# Altium schematic sheet Sheet3.SchDoc(Sheet3)
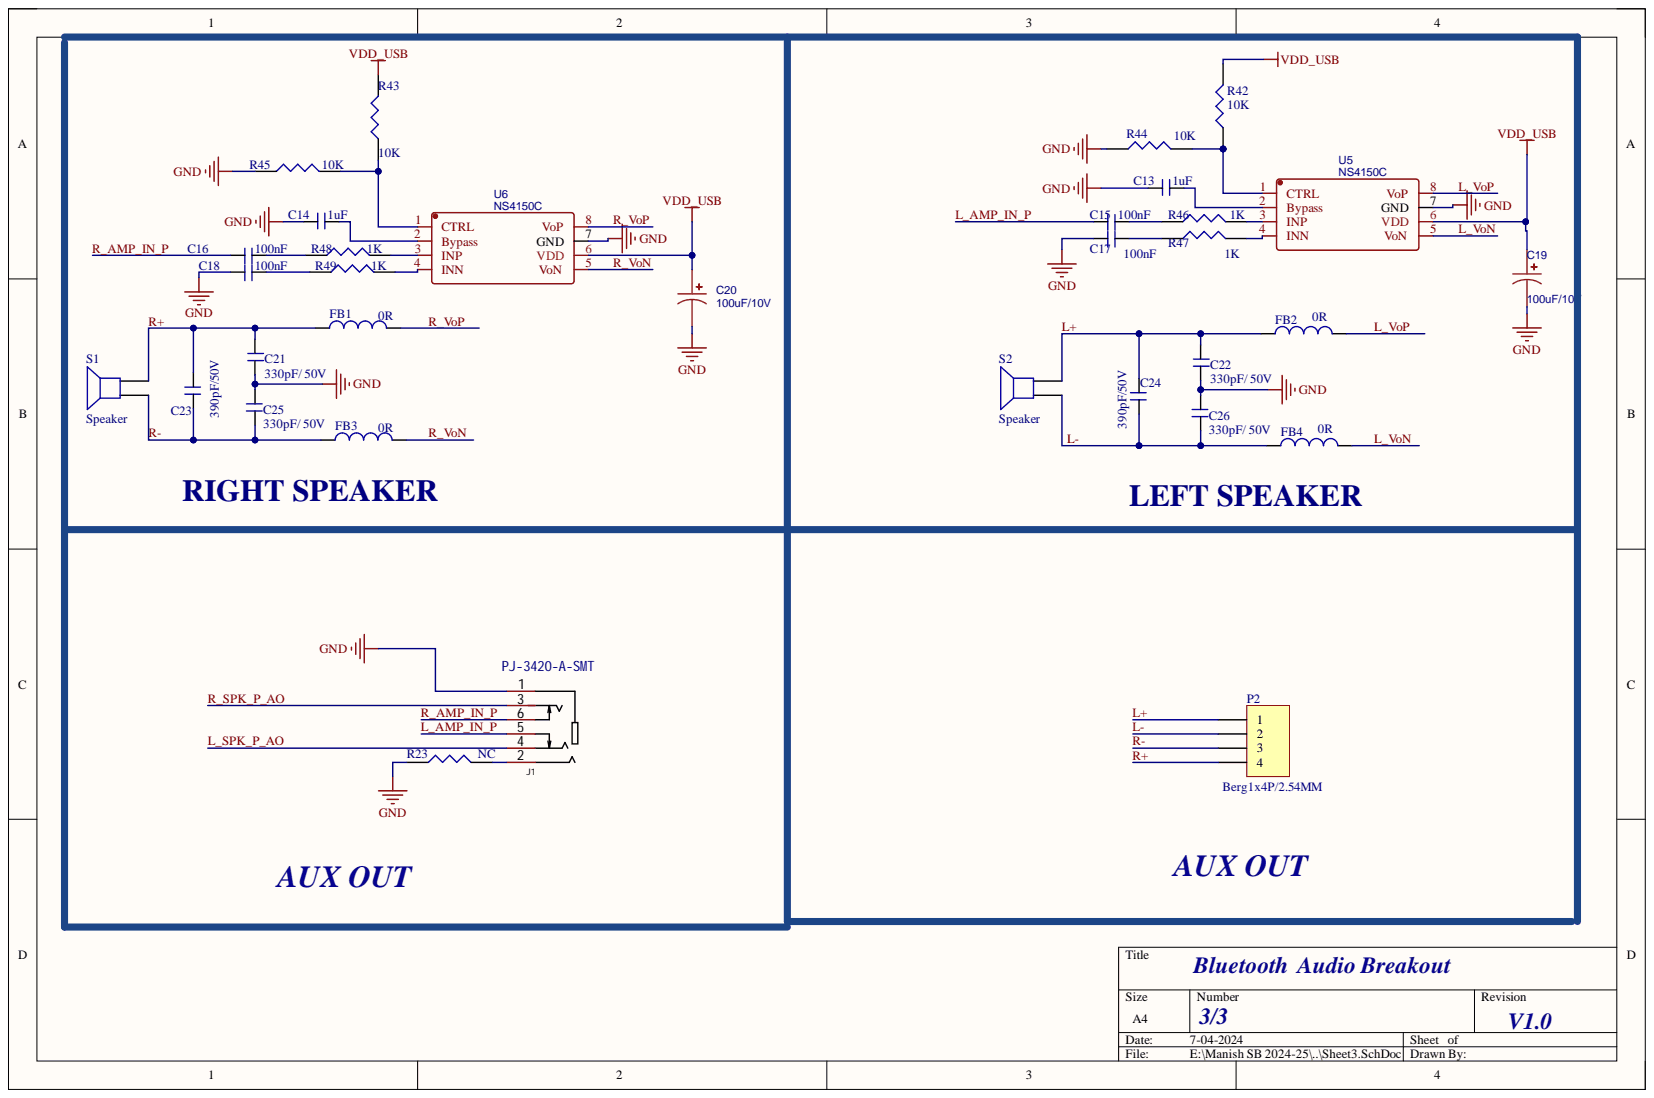
[diagram p0: Sheet3.SchDoc]

## Parts
C13  1uF  [C_0603]
C14  1uF  [C_0603]
C15  100nF  [C_0603]
C16  100nF  [C_0603]
C17  100nF  [C_0603]
C18  100nF  [C_0603]
C19  100uF/10V  [CASE-B-3528]
C20  100uF/10V  [CASE-B-3528]
C21  330pF/ 50V  [C_0603]
C22  330pF/ 50V  [C_0603]
C23  390pF/50V  [C_0603]
C24  390pF/50V  [C_0603]
C25  330pF/ 50V  [C_0603]
C26  330pF/ 50V  [C_0603]
FB1  0R  [R_0603]
FB2  FB  value 0R  [R_0603]
FB3  FB  value 0R  [R_0603]
FB4  FB  value 0R  [R_0603]
J1  PJ-3420-A-SMT  [3.5mm_PJ-3420]
P2  Berg1x4P/2.54MM  [4P_TH_2.54MM]
R23  NC  [R_0603]
R42  10K  [R_0603]
R43  10K  [R_0603]
R44  10K  [R_0603]
R45  10K  [R_0603]
R46  1K  [R_0603]
R47  1K  [R_0603]
R48  1K  [R_0603]
R49  1K  [R_0603]
S1  Speaker  [JST2P_P2.0mm]
S2  Speaker  [JST2P_P2.0mm]
U5  NS4150C  [SOP 8P]
U6  NS4150C  [SOP 8P]

## Nets
GND: C13.1 C14.1 C17.2 C18.2 C19.2 C20.2 C21.2 C22.2 C25.2 C26.2 J1.1 R23.2 R44.1 R45.1 U5.7(GND) U6.7(GND)
VDD_USB: C19.1 C20.1 R42.2 R43.2 U5.6(VDD) U6.6(VDD)
L+: C22.1 C24.2 FB2.1 P2.1 S2.2
L-: C24.1 C26.1 FB4.1 P2.2 S2.1
L_AMP_IN_P: C15.2 J1.5
L_SPK_P_AO: J1.4
L_VoN: FB4.2 U5.5(VoN)
L_VoP: FB2.2 U5.8(VoP)
R+: C21.1 C23.2 FB1.1 P2.4 S1.2
R-: C23.1 C25.1 FB3.1 P2.3 S1.1
R_AMP_IN_P: C16.2 J1.6
R_SPK_P_AO: J1.3
R_VoN: FB3.2 U6.5(VoN)
R_VoP: FB1.2 U6.8(VoP)
NetC13_2: C13.2 U5.2(Bypass)
NetC14_2: C14.2 U6.2(Bypass)
NetC15_1: C15.1 R46.1
NetC16_1: C16.1 R48.1
NetC17_1: C17.1 R47.1
NetC18_1: C18.1 R49.1
NetJ1_2: J1.2 R23.1
NetR42_1: R42.1 R44.2 U5.1(CTRL)
NetR43_1: R43.1 R45.2 U6.1(CTRL)
NetR46_2: R46.2 U5.3(INP)
NetR47_2: R47.2 U5.4(INN)
NetR48_2: R48.2 U6.3(INP)
NetR49_2: R49.2 U6.4(INN)

## Library data of the parts
C13-C18 C21-C26 = CAP_NONPOLAR: Component Kind: Standard; Library Name: dxp_pasl_lib.IntLib
C19 C20 = 270uF: BOM_Manufacturer: rubycon(红宝石); BOM_Manufacturer Part: 100ZL270MEFC12.5X35; Contributor: lcsc; nameAlias: Capacitance; BOM_JLCPCB Part Class: Extended Part; BOM_Supplier: LCSC; BOM_Supplier Part: C1598036; Component Kind: Standard; link: https://atta.szlcsc.com/upload/public/pdf/source/20210810/D32E969E718DCFB364F518B2A745C406.pdf; spicePre: C; spiceSymbolName: 100ZL270MEFC12.5X35; Library Name: MIX.SchLib
FB1-FB4 = Inductor: Code_IEC: 1005; Code_JEITA: 0402; Component Kind: Standard; Description: Inductor; LatestRevisionDate: 29-May-2009; LatestRevisionNote: IPC-7351 Footprint Added.; Library Name: Miscellaneous Devices.IntLib; PackageDescription: Chip Inductor; PackageReference: 0402-A; Published: 8-Jun-2000; Signal Integrity: Inductor; Simulation: INDUCTOR; Publisher: Altium Limited
  FB1: Value: 0R
J1 = PJ-3420-A-SMT: Manufacturer: XKB Connectivity(中国星坤); Add into BOM: yes; Connector Type: 3.5mm耳机座; Current Rating (Max): 500mA; Soldering Temperature(Max): 235℃; Symbol: PJ-3537-X; Voltage Rating (Max): 30V; Component Kind: Standard; Convert to PCB: yes; Datasheet: https://atta.szlcsc.com/upload/public/pdf/source/20211015/FA11597DF53DC331FB6A72D64BA50234.pdf; Device: PJ-3420-A-SMT; JLCPCB Part Class: Extended Part; LCSC Part Name: 3.5mm耳机座; Manufacturer Part: PJ-3420-A-SMT; Operating Temperature Range: -20℃~+70℃; Supplier: LCSC; Supplier Footprint: SMD; Supplier Part: C319102
P2 = Header 4: Header, 4-Pin; Component Kind: Standard; LatestRevisionDate: 17-Jul-2002; LatestRevisionNote: Re-released for DXP Platform.; Library Name: Miscellaneous Connectors.IntLib; Signal Integrity: Connector; Publisher: Altium Limited
R23 R42-R49 = RES: Component Kind: Standard; Library Name: dxp_pasl_lib.IntLib
S1 S2 = Speaker: Loudspeaker; Component Kind: Standard; LatestRevisionDate: 17-Jul-2002; LatestRevisionNote: Re-released for DXP Platform.; Library Name: Miscellaneous Devices.IntLib; PackageReference: PIN2; Published: 24-Mar-1999; Publisher: Altium Limited
U5 U6 = NS4150C: Manufacturer: Nsiway(纳芯威); Contributor: LCSC; Component Kind: Standard; JLCPCB Part Class: Extended Part; Manufacturer Part: NS4150C; spicePre: U; spiceSymbolName: NS4150C; Supplier: LCSC; Supplier Part: C2875838
